# Altium schematic sheet PAGE6-COMMUNICATION INTERFACES.SchDoc
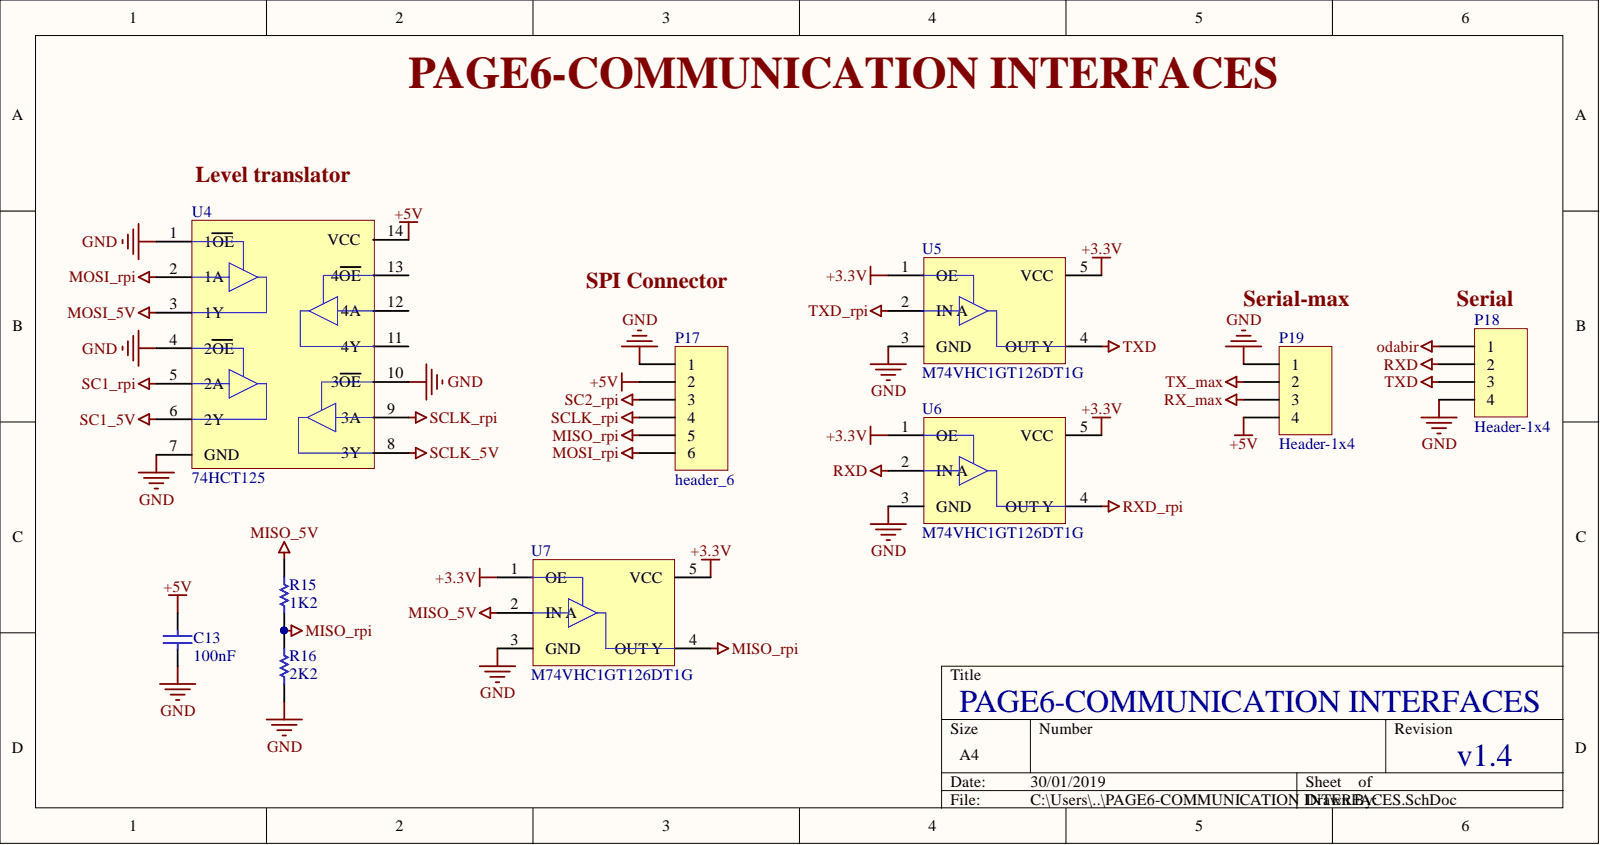
[diagram p0: PAGE6-COMMUNICATION INTERFACES.SchDoc]

## Parts
C13  value 100nF  [1206_cap]  (hidden comment: cap_smd1206)
P17  header_6  [HDR_1X6]
P18  Header-1x4  [BrxBeliKon]
P19  Header-1x4  [BrxBeliKon]
R15  value 1K2  [1206_res]  (hidden comment: res)
R16  value 2K2  [1206_res]  (hidden comment: res)
U4  74HCT125  [SOIC-127]
U5  M74VHC1GT126DT1G  [SOT-353]
U6  M74VHC1GT126DT1G  [SOT-353]
U7  M74VHC1GT126DT1G  [SOT-353]

## Nets
+3.3V: U5.1(OE) U5.5(VCC) U6.1(OE) U6.5(VCC) U7.1(OE) U7.5(VCC)
+5V: C13.1 P17.2 P19.4 U4.14(VCC)
GND: C13.2 P17.1 P18.4 P19.1 R16.1 U4.1(1OE) U4.4(2OE) U4.7(GND) U4.10(3OE) U5.3(GND) U6.3(GND) U7.3(GND)
MISO_5V: R15.2 U7.2(IN_A)
MISO_RPI: P17.5 R15.1 R16.2 U7.4(OUT_Y)
MOSI_5V: U4.3(1Y)
MOSI_RPI: P17.6 U4.2(1A)
ODABIR: P18.1
RX_MAX: P19.3
RXD: P18.2 U6.2(IN_A)
RXD_RPI: U6.4(OUT_Y)
SC1_5V: U4.6(2Y)
SC1_RPI: U4.5(2A)
SC2_RPI: P17.3
SCLK_5V: U4.8(3Y)
SCLK_RPI: P17.4 U4.9(3A)
TX_MAX: P19.2
TXD: P18.3 U5.4(OUT_Y)
TXD_RPI: U5.2(IN_A)

Not connected: U4 11(4Y) 12(4A) 13(4OE)

## Library data of the parts
C13 = cap_smd1206: Capacitor; Component Kind: Standard; LatestRevisionDate: 17-Jul-2002; LatestRevisionNote: Re-released for DXP Platform.; PackageReference: RAD-0.3; Published: 8-Jun-2000; Signal Integrity: Cap; Simulation: CAP; Library Name: hepek_lib.SchLib; Publisher: Altium Limited
P17 = header_6: Header, 6-Pin; Component Kind: Standard; LatestRevisionDate: 17-Jul-2002; LatestRevisionNote: Re-released for DXP Platform.; Signal Integrity: Connector; Library Name: hepek_lib.SchLib; Publisher: Altium Limited
P18 P19 = BrxBeliKon: Header, 4-Pin; Component Kind: Standard; LatestRevisionDate: 17-Jul-2002; LatestRevisionNote: Re-released for DXP Platform.; Signal Integrity: Connector; Library Name: hepek_lib.SchLib; Publisher: Altium Limited
R15 R16 = res: Resistor; Component Kind: Standard; LatestRevisionDate: 17-Jul-2002; LatestRevisionNote: Re-released for DXP Platform.; PackageReference: AXIAL-0.3; Published: 8-Jun-2000; Signal Integrity: Res; Simulation: RESISTOR; Library Name: hepek_lib.SchLib; Publisher: Altium Limited
U4 = 74HCT125: Component Kind: Standard; Library Name: hepek_lib.SchLib
U5-U7 = M74VHC1GT126DT1G: Component Kind: Standard; Library Name: hepek_lib.SchLib
